# Altium schematic sheet Sheet5.SchDoc
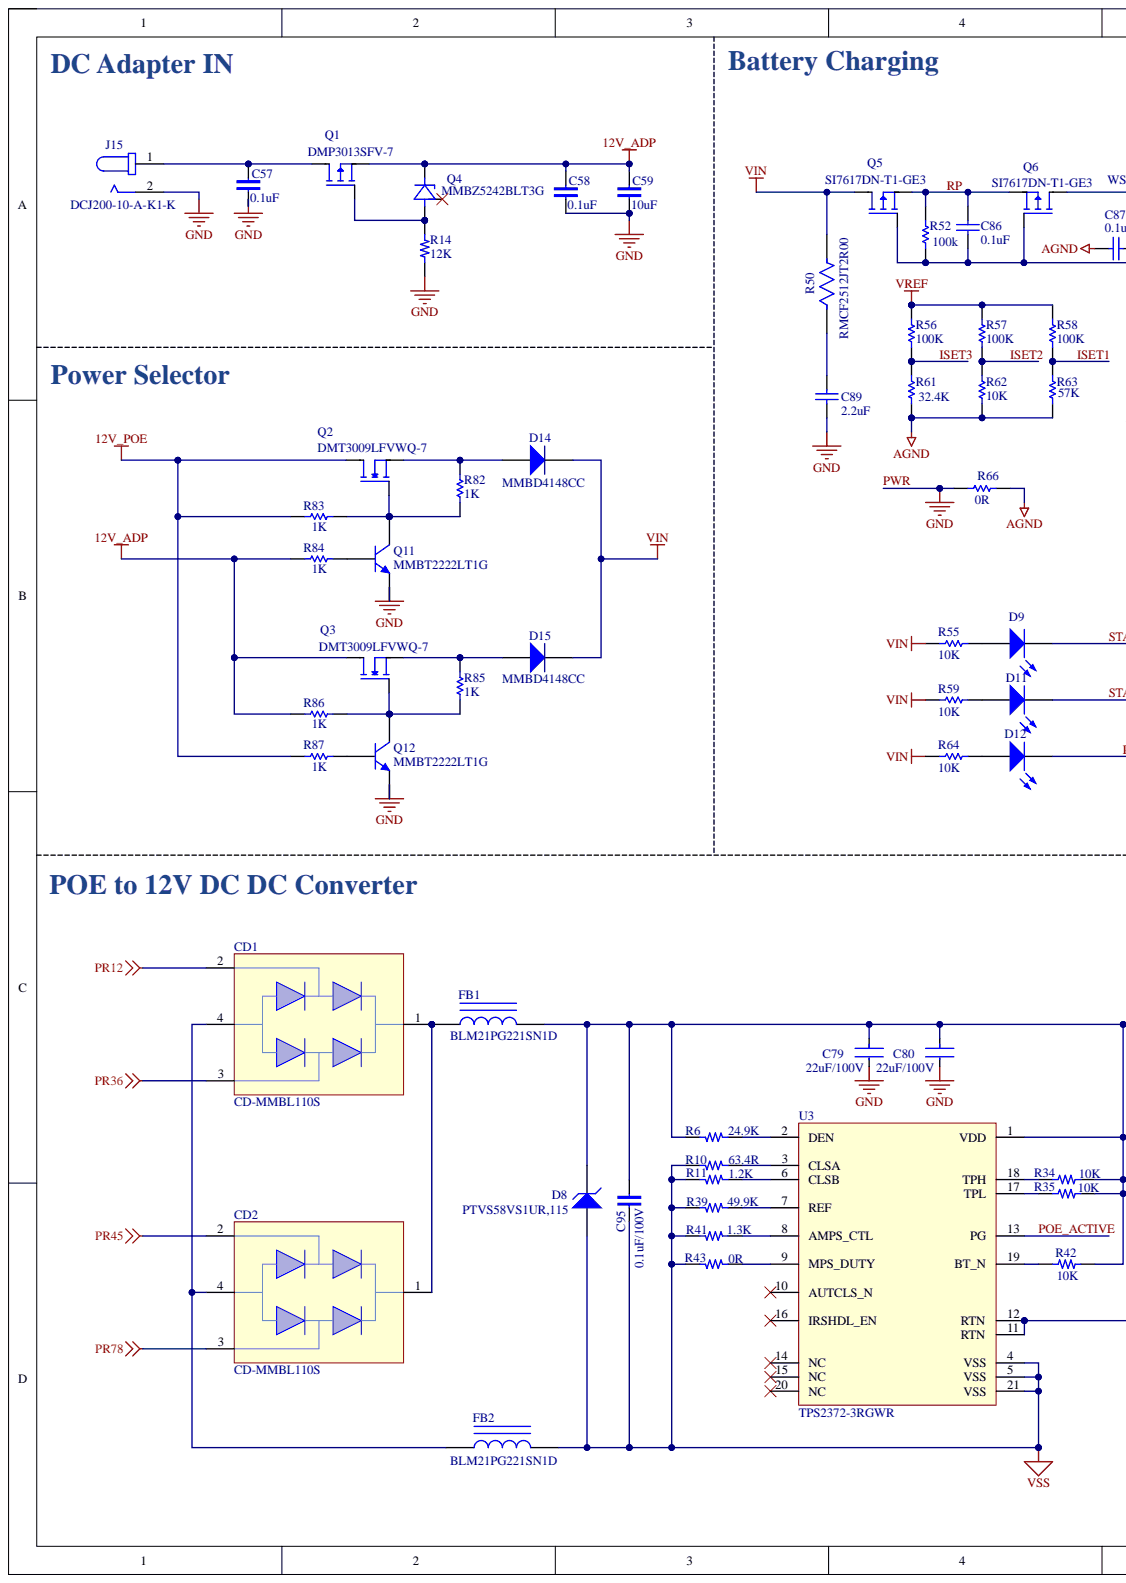
[diagram p0: Sheet5.SchDoc, left]
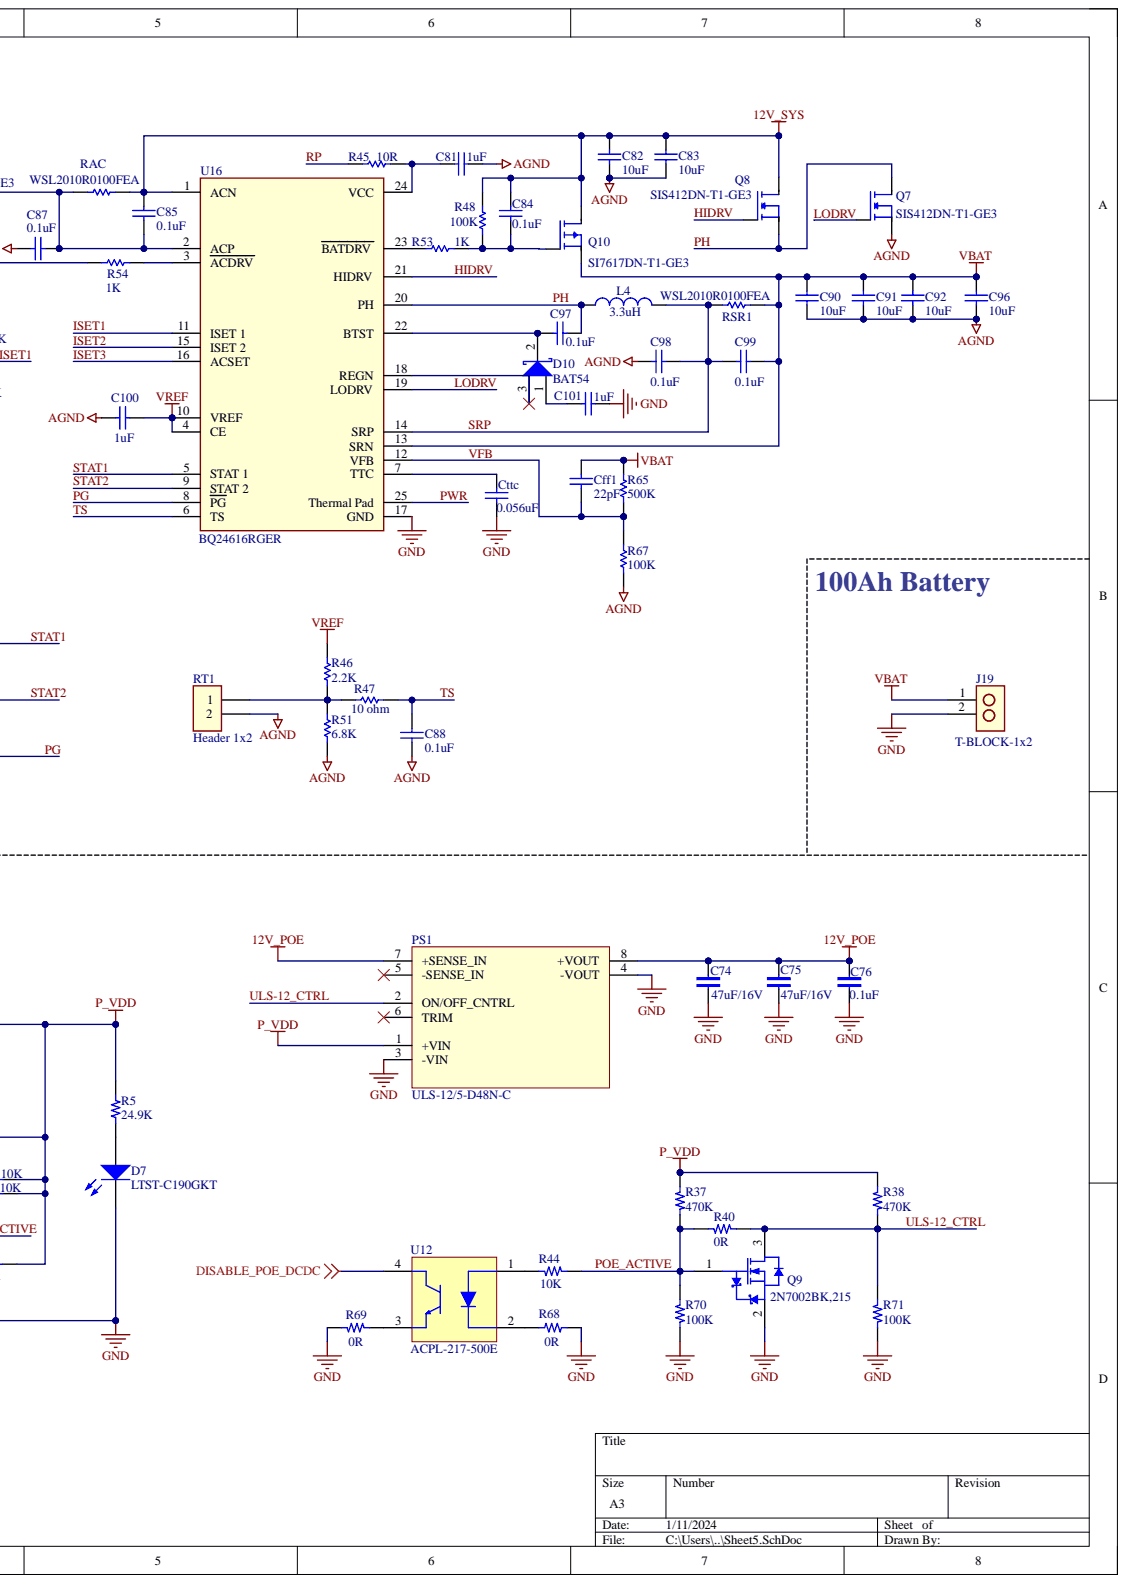
[diagram p1: Sheet5.SchDoc, right]

## Parts
C57  (no value)  [C-0603/1608]
C58  (no value)  [C-0603/1608]
C59  (no value)  [C-1206/3216]
C74  (no value)  [C-1206/3216]
C75  (no value)  [C-1206/3216]
C76  (no value)  [C-0402/1005]
C79  (no value)  [FP-KRM55W-6_1_5_3_6_7_1_2-MFG]
C80  (no value)  [FP-KRM55W-6_1_5_3_6_7_1_2-MFG]
C81  value 1uF  [C-0603/1608]
C82  value 10uF  [C-0805/2012]
C83  value 10uF  [C-0805/2012]
C84  value 0.1uF  [R-0402/1005]
C85  value 0.1uF  [C-0402/1005]
C86  value 0.1uF  [C-0402/1005]
C87  value 0.1uF  [C-0402/1005]
C88  value 0.1uF  [C-0402/1005]
C89  value 2.2uF  [C1206]
C90  value 10uF  [C-0805/2012]
C91  value 10uF  [C-0805/2012]
C92  value 10uF  [C-0805/2012]
C95  (no value)  [C-0402/1005]
C96  value 10uF  [C-0805/2012]
C97  value 0.1uF  [C-0402/1005]
C98  value 0.1uF  [C-0402/1005]
C99  value 0.1uF  [C-0402/1005]
C100  value 1uF  [C-0603/1608]
C101  value 1uF  [C-0603/1608]
CD1  (no value)  [CD-MMBL110S]
CD2  (no value)  [CD-MMBL110S]
Cff1  value 22pF  [C-0402/1005]
Cttc  value 0.056uF  [C-1206/3216]
D7  (no value)  [LEDC1608X35N]
D8  (no value)  [DIO_PMEG4030ER,115]
D9  (no value)  [LED-0402-GREEN]
D10  (no value)  [SOT-23-3]
D11  (no value)  [LED-0402-GREEN]
D12  (no value)  [LED-0402-GREEN]
D14  (no value)  [SOT-23-3]
D15  (no value)  [SOT-23-3]
FB1  (no value)  [BEADC2012X105N]
FB2  (no value)  [BEADC2012X105N]
J15  (no value)  [GCT_DCJ200-10-A-XX-X_REVA]
J19  (no value)  [Terminal Block-1x2-5.08mm]
L4  value 3.3uH  [IND_SRN6045TA-3R3Y]
PS1  MP: ULS-12/5-D48N-C  [CONV_ULS-12/5-D48N-C]
Q1  (no value)  [POWERDI3333-8_UX_DIO]
Q2  (no value)  [POWERDI3333-8_UX_DIO]
Q3  (no value)  [POWERDI3333-8_UX_DIO]
Q4  (no value)  [SOT-23-3]
Q5  (no value)  [POWERDI3333-8_UX_DIO]
Q6  (no value)  [POWERDI3333-8_UX_DIO]
Q7  (no value)  [POWERDI3333-8_UX_DIO]
Q8  (no value)  [POWERDI3333-8_UX_DIO]
Q9  PartNumber: 2N7002BK,215  [NXP-SOT23_M]
Q10  (no value)  [POWERDI3333-8_UX_DIO]
Q11  (no value)  [SOT-23-3]
Q12  (no value)  [SOT-23-3]
R5  (no value)  [R-0402/1005]
R6  (no value)  [R-0402/1005]
R10  (no value)  [R-0402/1005]
R11  (no value)  [R-0402/1005]
R14  (no value)  [R-0402/1005]
R34  (no value)  [R-0402/1005]
R35  (no value)  [R-0402/1005]
R37  (no value)  [R-0402/1005]
R38  (no value)  [R-0402/1005]
R39  (no value)  [R-0402/1005]
R40  (no value)  [R-0402/1005]
R41  (no value)  [R-0402/1005]
R42  (no value)  [R-0402/1005]
R43  (no value)  [R-0402/1005]
R44  (no value)  [R-0402/1005]
R45  value 10R  [R-0805/2012]
R46  value 2.2K  [R-0402/1005]
R47  value 10 ohm  [R-0402/1005]
R48  value 100K  [R-0402/1005]
R50  (no value)  [FP-RMCF2512-IPC_A]
R51  value 6.8K  [R-0402/1005]
R52  value 100k  [R-0402/1005]
R53  value 1K  [R-0402/1005]
R54  value 1K  [R-0402/1005]
R55  value 10K  [R-0402/1005]
R56  value 100K  [R-0402/1005]
R57  value 100K  [R-0402/1005]
R58  value 100K  [R-0402/1005]
R59  value 10K  [R-0402/1005]
R61  value 32.4K  [R-0402/1005]
R62  value 10K  [R-0402/1005]
R63  value 57K  [R-0402/1005]
R64  value 10K  [R-0402/1005]
R65  value 500K  [R-0402/1005]
R66  value 0R  [R-1210]
R67  value 100K  [R-0402/1005]
R68  (no value)  [R-0402/1005]
R69  (no value)  [R-0402/1005]
R70  (no value)  [R-0402/1005]
R71  (no value)  [R-0402/1005]
R82  value 1K  [R-0402/1005]
R83  value 1K  [R-0402/1005]
R84  value 1K  [R-0402/1005]
R85  value 1K  [R-0402/1005]
R86  value 1K  [R-0402/1005]
R87  value 1K  [R-0402/1005]
RAC  (no value)  [RESC5125X89X51LL25T25]
RSR1  (no value)  [RESC5125X89X51LL25T25]
RT1  (no value)  [PIN HEADER 1x2 2.54mm]
U3  Manufacturer_Part_Number: TPS2372-3RGWR  [QFN65P500X500X100-21N]
U12  (no value)  [AVAG-ACPL-217-4_M]
U16  (no value)  [QFN50P400X400X100-25N]

## Nets
12V_ADP: C58.1 C59.1 Q1.S Q3.D Q4.3 R84.1 R86.1
12V_POE: C74.1 C75.1 C76.1 PS1.7(+SENSE_IN) PS1.8(+VOUT) Q2.D R83.1 R87.1
12V_SYS: C82.1 C83.1 C84.2 C85.2 Q8.D Q10.D R48.2 RAC.2 U16.1(ACN)
AGND: C81.2 C82.2 C83.2 C87.1 C88.1 C90.2 C91.2 C92.2 C96.2 C98.2 C100.1 Q7.S R51.2 R61.2 R62.2 R63.2 R66.1 R67.1 RT1.2
DISABLE_POE_DCDC: U12.4
P_VDD: C79.1 C80.1 C95.1 D8.2 FB1.2 PS1.1(+VIN) R5.1 R6.2 R34.2 R35.2 R37.1 R38.1 R42.2 U3.1(VDD)
PR12: CD1.2
PR36: CD1.3
PR45: CD2.2
PR78: CD2.3
VBAT: C90.1 C91.1 C92.1 C96.1 C99.1 Cff1.2 J19.1 Q10.S R65.2 RSR1.2 U16.13(SRN)
VIN: D14.2 D15.2 Q5.D R50.2 R55.2 R59.2 R64.2
VREF: C100.2 R46.1 R56.1 R57.1 R58.1 U16.4(CE) U16.10(VREF)
VSS: C95.2 D8.1 FB2.2 R10.2 R11.2 R39.2 R41.2 R43.2 U3.4(VSS) U3.5(VSS) U3.21(VSS)
HIDRV: Q8.G U16.21(HIDRV)
ISET1: R58.2 R63.1 U16.11(ISET_1)
ISET2: R57.2 R62.1 U16.15(ISET_2)
ISET3: R56.2 R61.1 U16.16(ACSET)
LODRV: Q7.G U16.19(LODRV)
PG: D12.2 U16.8(PG)
PH: C97.1 L4.1 Q7.D Q8.S U16.20(PH)
POE_ACTIVE: Q9.1 R37.2 R40.1 R44.1 R70.1 U3.13(PG)
PWR: C57.2 C58.2 C59.2 C74.2 C75.2 C76.2 C79.2 C80.2 C89.1 C101.2 Cttc.2 D7.C J15.2 J19.2 PS1.3(-VIN) PS1.4(-VOUT) Q9.2 Q11.1 Q12.1 R14.1 R66.2 R68.1 R69.1 R70.2 R71.2 U3.11(RTN) U3.12(RTN) U16.17(GND) U16.25(Thermal_Pad)
RP: C86.2 Q5.S Q6.S R45.1 R52.2
SRP: C98.1 C99.2 L4.2 RSR1.1 U16.14(SRP)
STAT1: D9.2 U16.5(STAT_1)
STAT2: D11.2 U16.9(STAT_2)
TS: C88.2 R47.1 U16.6(TS)
ULS-12_CTRL: PS1.2(ON/OFF_CNTRL) Q9.3 R38.2 R40.2 R71.1
VFB: Cff1.1 R65.1 R67.2 U16.12(VFB)
NetC57_1: C57.1 J15.1 Q1.D
NetC81_1: C81.1 R45.2 U16.24(VCC)
NetC84_1: C84.1 Q10.G R48.1 R53.1
NetC85_1: C85.1 C87.2 Q6.D RAC.1 U16.2(ACP)
NetC86_1: C86.1 Q5.G Q6.G R52.1 R54.1
NetC89_2: C89.2 R50.1
NetC97_2: C97.2 D10.2 U16.22(BTST)
NetC101_1: C101.1 D10.1
NetCD1_1: CD1.1 CD2.1 FB1.1
NetCD1_4: CD1.4 CD2.4 FB2.1
NetCttc_1: Cttc.1 U16.7(TTC)
NetD7_A: D7.A R5.2
NetD9_1: D9.1 R55.1
NetD10_3: D10.3 U16.18(REGN)
NetD11_1: D11.1 R59.1
NetD12_1: D12.1 R64.1
NetD14_1: D14.1 Q2.S R82.2
NetD15_1: D15.1 Q3.S R85.2
NetQ1_G: Q1.G Q4.1 R14.2
NetQ2_G: Q2.G Q11.3 R82.1 R83.2
NetQ3_G: Q3.G Q12.3 R85.1 R86.2
NetQ11_2: Q11.2 R84.2
NetQ12_2: Q12.2 R87.2
NetR6_1: R6.1 U3.2(DEN)
NetR10_1: R10.1 U3.3(CLSA)
NetR11_1: R11.1 U3.6(CLSB)
NetR34_1: R34.1 U3.18(TPH)
NetR35_1: R35.1 U3.17(TPL)
NetR39_1: R39.1 U3.7(REF)
NetR41_1: R41.1 U3.8(AMPS_CTL)
NetR42_1: R42.1 U3.19(BT_N)
NetR43_1: R43.1 U3.9(MPS_DUTY)
NetR44_2: R44.2 U12.1
NetR46_2: R46.2 R47.2 R51.1 RT1.1
NetR53_2: R53.2 U16.23(BATDRV)
NetR54_2: R54.2 U16.3(ACDRV)
NetR68_2: R68.2 U12.2
NetR69_2: R69.2 U12.3

Not connected: PS1 5(-SENSE_IN) 6(TRIM); Q4 2; U3 10(AUTCLS_N) 14(NC) 15(NC) 16(IRSHDL_EN) 20(NC)

## Library data of the parts
C57-C59 = (no symbol name): CAP CER 10UF 10V X7R 0805; Mfr. Part No.: GRM21BR71A106KA73K; Unit Price (USD): 0.19; Supplier: Digikey Electronics; Supplier Part No.: 490-14381-1-ND; Value: 10uF 10V
C74-C76 C95 = (no symbol name): CAP CER 0.1UF 50V X7R 0402; Mfr. Part No.: UMK105B7104KV-FR; Unit Price (USD): 0.15; Value: 100nF; Supplier: Digikey Electronics; Supplier Part No.: 587-3498-1-ND
C79 C80 = (no symbol name): Cap Ceramic 22uF 100V X7R ±15% SMD 2220 +125°C Emboss T/R; Lead/Base Style: J-Lead; Tolerance: 20%; Capacitance: 22uF; Case/Package: SMD/SMT; Max Operating Temperature: 125°C; Min Operating Temperature: -55°C; Mount: Surface Mount; Packaging: Tape and Reel; Voltage Rating: 100V
C81-C92 C96-C101 Cff1 Cttc = (no symbol name): Capacitor; LatestRevisionDate: 17-Jul-2002; LatestRevisionNote: Re-released for DXP Platform.; PackageDescription: Radial Cap, Thru-Hole; 2 Leads; 0.3 in Pin Spacing; PackageReference: RAD-0.3; Published: 8-Jun-2000; Publisher: Altium Limited
D7 D9 D11 D12 = (no symbol name): Typical INFRARED GaAs LED; LatestRevisionDate: 08-Jul-2005; LatestRevisionNote: Mech Layer 1 removed.; PackageDescription: LED; 2 Leads; PackageReference: LED-0; Published: 8-Jun-2000; Publisher: Altium Limited
D8 = (no symbol name): Zener Diode; LatestRevisionDate: 17-Jul-2002; LatestRevisionNote: Re-released for DXP Platform.; PackageDescription: Diode, Thru-Hole; 2 Leads; 0.7 in Pin Spacing; PackageReference: DIODE-0.7; Published: 8-Jun-2000; Publisher: Altium Limited
D10 = (no symbol name): Schottky Diode; Code_JEDEC: DO-214-AA; LatestRevisionDate: 17-Jul-2002; LatestRevisionNote: Re-released for DXP Platform.; PackageDescription: DO-214-AA/SMB; 2 C-Bend Leads; Body 5.3 x 3.6 mm, inc. leads (LxW); PackageReference: SMB; Published: 8-Jun-2000; Publisher: Altium Limited
D14 D15 = (no symbol name): Diode GENERAL; Code_JEDEC: DO-214-AA; LatestRevisionDate: 17-Jul-2002; LatestRevisionNote: Re-released for DXP Platform.; PackageDescription: DO-214-AA/SMB; 2 C-Bend Leads; Body 5.3 x 3.6 mm, inc. leads (LxW); PackageReference: SMB; Published: 8-Jun-2000; Publisher: Altium Limited
FB1 FB2 = (no symbol name): Magnetic-Core Inductor; LatestRevisionDate: 17-Jul-2002; LatestRevisionNote: Re-released for DXP Platform.; PackageDescription: Axial Device, Thru-Hole; 2 Leads; 0.9 in Pin Spacing; PackageReference: AXIAL-0.9; Published: 24-Mar-1999; Publisher: Altium Limited
J15 = (no symbol name): CONN PWR JACK 2X5.5MM SOLDER; Mfr. Part No.: PJ-037AH; Unit Price (USD): 0.71; Supplier: Digikey Electronics; Supplier Part No.: CP-037AH-ND
L4 = (no symbol name): Inductor; Code_IEC: 1005; Code_JEITA: 0402; LatestRevisionDate: 29-May-2009; LatestRevisionNote: IPC-7351 Footprint Added.; PackageDescription: Chip Inductor; PackageReference: 0402-A; Published: 8-Jun-2000; Publisher: Altium Limited
PS1 = (no symbol name): Isolated Module DC DC Converter 1 Output 12V - - 5A 36V - 75V Input; MF: Murata Power Solutions Inc.; Availability: In Stock; Check_prices: https://www.snapeda.com/parts/ULS-12/5-D48N-C/Murata/view-part/?ref=eda; ComponentLink1Description: New link; ComponentLink1URL: VOUT-; Package: None; Price: None; Purchase-URL: https://pricing.snapeda.com/search/part/ULS-12/5-D48N-C/?ref=eda; SnapEDA_Link: https://www.snapeda.com/parts/ULS-12/5-D48N-C/Murata/view-part/?ref=snap
Q9 = (no symbol name): N-Channel Trench MOSFET, 60 V, 0.35 A, -55 to 150 degC, 3-Pin SOT23, Tape and Reel; Manufacturer: NXP Semiconductors; Automotive Qualified: Y; Channel Type: N; ID [max] (A): 0.35; IDM [max] (A): 1.2; Packing: Tape & Reel; VDS [max] (V): 60; VGSth [typ] (V): 1.6; Code_JEDEC: TO-236AB; ComponentLink1Description: Manufacturer URL; ComponentLink1URL: http://www.nxp.com/; ComponentLink2Description: Datasheet; ComponentLink2URL: http://www.nxp.com/documents/data_sheet/2N7002BK.pdf; ComponentLink3Description: Package Specification; ComponentLink3URL: http://www.nxp.com/documents/outline_drawing/sot023_po.pdf; DatasheetVersion: Rev. 1; Mounting Technology: Surface Mount; PackageDescription: 3-Pin Small Outline Transistor Package, Body 2.9 x 1.3 mm, Pitch 0.95 mm; PackageReference: SOT23; PackageVersion: 06-03-16, 03/2006
Q11 Q12 = (no symbol name): NPN General Purpose Amplifier; ComponentLink1Description: Manufacturer Link; ComponentLink1URL: http://www.fairchildsemi.com/; ComponentLink2Description: Datasheet; ComponentLink2URL: http://www.fairchildsemi.com/ds/2N%2F2N3904.pdf; DatasheetVersion: 1997; LatestRevisionDate: 15-Jan-2003; LatestRevisionNote: PCB Footprint 'BCY-W3/D4.7' replaced; PackageDescription: TO, Flat Index; 3 In-Line, Axial Leads; Body Dia. 4.5mm; Leads 0.51 x 0.48 mm (max); PackageReference: TO-92A; PackageVersion: Sep-1998; Published: 8-Jun-2000; Publisher: Altium Limited
R5 R6 R10 R11 R34 R35 R37-R44 R68-R71 = (no symbol name): RES SMD 15K OHM 1% 1/16W 0402; Mfr. Part No.: MCR01MZPF1502; Unit Price (USD): 0.1; Value: 15k 1%; Supplier: Digikey Electronics; Supplier Part No.: RHM15.0KLTR-ND
R14 = (no symbol name): RES SMD 51 OHM 0.5% 1/16W 0402; Mfr. Part No.: ERA-2AED510X; Unit Price (USD): 0.21; Value: 51R; Supplier: Digikey Electronics; Supplier Part No.: P51DECT-ND
R45-R48 R51-R53 R55-R59 R61-R67 R82-R87 = (no symbol name): Semiconductor Resistor; LatestRevisionDate: 17-Jul-2002; LatestRevisionNote: Re-released for DXP Platform.; PackageDescription: Axial Device, Thru-Hole; 2 Leads; 0.5 in Pin Spacing; PackageReference: AXIAL-0.5; Published: 8-Jun-2000; Publisher: Altium Limited
R50 = (no symbol name): 2Ω ±5% 1W 2512 Thick Film Chip Resistor AEC-Q200 compliant; Resistance: 2R; Temperature Coefficient: 400ppm/°C; Case Code (Imperial): 2512; Case Code (Metric): 6432; Case/Package: 2512; Construction: Rectangular; Contact Plating: Tin; Depth: 3.2mm; Features: Automotive AEC-Q200; Height: 0.028inch; Lead Free: Lead Free; Length: 0.248inch; Max Operating Temperature: 155°C; Max Power Dissipation: 1W; Military Standard: Not; Min Operating Temperature: -55°C; Mount: Surface Mount; Number of Terminations: 2; Packaging: Tape and Reel; Power Rating: 1W; Radiation Hardening: No; Resistor Type: Thick Film; RoHS Compliant: Yes; Series: RMCF; Size Code: 2512; Tolerance: 5%; Width: 0.126inch
R54 = (no symbol name): Resistor; LatestRevisionDate: 17-Jul-2002; LatestRevisionNote: Re-released for DXP Platform.; PackageDescription: Axial Device, Thru-Hole; 2 Leads; 0.3 in Pin Spacing; PackageReference: AXIAL-0.3; Published: 8-Jun-2000; Publisher: Altium Limited
RAC RSR1 = (no symbol name): Chip Resistor, 10 mOhm, +/- 1%, 0.5 W, -65 to 170 degC, 2010 (5025 Metric), RoHS, Tape and Reel; Manufacturer: Vishay; Brand: Vishay/Dale; Case Height: 0.635mm; Case Length: 5mm; Case Width: 2.5mm; Power Rating: 500mW(1/2W); Resistance: 0.01Ohms; Temperature Coefficient: 75PPM/C; Unit Weight: 0.001372oz; Case Code - in: 2010; Case Code - mm: 5025; Datasheet URL: http://www.vishay.com/docs/30100/wsl.pdf; Datasheet Version: 07/2016; Factory Pack Quantity: 4000; Manufacturer URL: http://www.vishay.com/; Mounting Technology: SurfaceMount; Operating Temperature Range: -65Cto+170C; Package / Case: 2010(5025metric); Package Description: 2-Pin Surface Mount Device, Body 5.08 x 2.54 mm; Package Reference: 2010; Packaging: Reel; Product: MetalElementCurrentSensingResistors; Product Category: CurrentSenseResistors-SMD; RoHS: Details; Series: WSL; Termination Style: SMD/SMT; Tolerance: 1%; Tradename: PowerMetalStrip; Type: PowerMetalStripResistors,LowValue(downto0.0005Ohms),SurfaceMount
RT1 = (no symbol name): CONN HEADER VERT 3POS 2.54MM; Mfr. Part No.: 75160-156-03LF; Unit Price (USD): 0.74; Value: 51R; Supplier: Digikey Electronics; Supplier Part No.: 609-75160-156-03LF-ND
U3 = (no symbol name): No Description Available; Mfr_Name: Texas Instruments; RefDes: RefDes; Type: DEV; Copyright: Copyright (C) 2023 Ultra Librarian. All rights reserved.
U12 = (no symbol name): DC Input, Half-Pitch Phototransistor Optocoupler, 5 V, -55 to 110 degC, 4-Pin SOIC, RoHS, Tape and Reel; Brand: AvagoTechnologies; Configuration: 1Channel; Current Transfer Ratio: 600%; Isolation Voltage: 3000Vrms; Maximum Collector Current: 50mA; Maximum Collector Emitter Saturation Voltage: 0.4V; Maximum Collector Emitter Voltage: 80V; Maximum Fall Time: 3us; Maximum Forward Diode Voltage: 1.4V; Maximum Power Dissipation: 200mW; Maximum Reverse Diode Voltage: 6V; Maximum Rise Time: 2us; Output Device: NPNPhototransistor; Datasheet URL: https://datasheet.ciiva.com/15118/getdatasheetpartid-243403-15118938.pdf; Datasheet Version: Aug-11; Factory Pack Quantity: 3000; Input Type: DC; Manufacturer: AvagoTechnologies; Manufacturer URL: http://www.avagotech.com/; Maximum Input Diode Current: 50mA; Maximum Operating Temperature: +110C; Minimum Operating Temperature: -55C; Number of Channels: 1Channel; Number of Channels per Chip: 1Channel; Output Type: DC; Package / Case: SOIC-4; Package Description: 4-Pin Small Outline Integrated Circuits, Body 4.4 x 2.6 mm, Pitch 1.27 mm; Package Reference: SOIC-4; Packaging: Reel; Product Category: TransistorOutputOptocouplers; RoHS: Details
CD1 CD2 J19 Q1-Q8 Q10 U16 = (no symbol name)
  J19 Q1-Q8 Q10: Supplier: Digikey Electronics
